# Altium schematic sheet CL10_FPGA.SchDoc
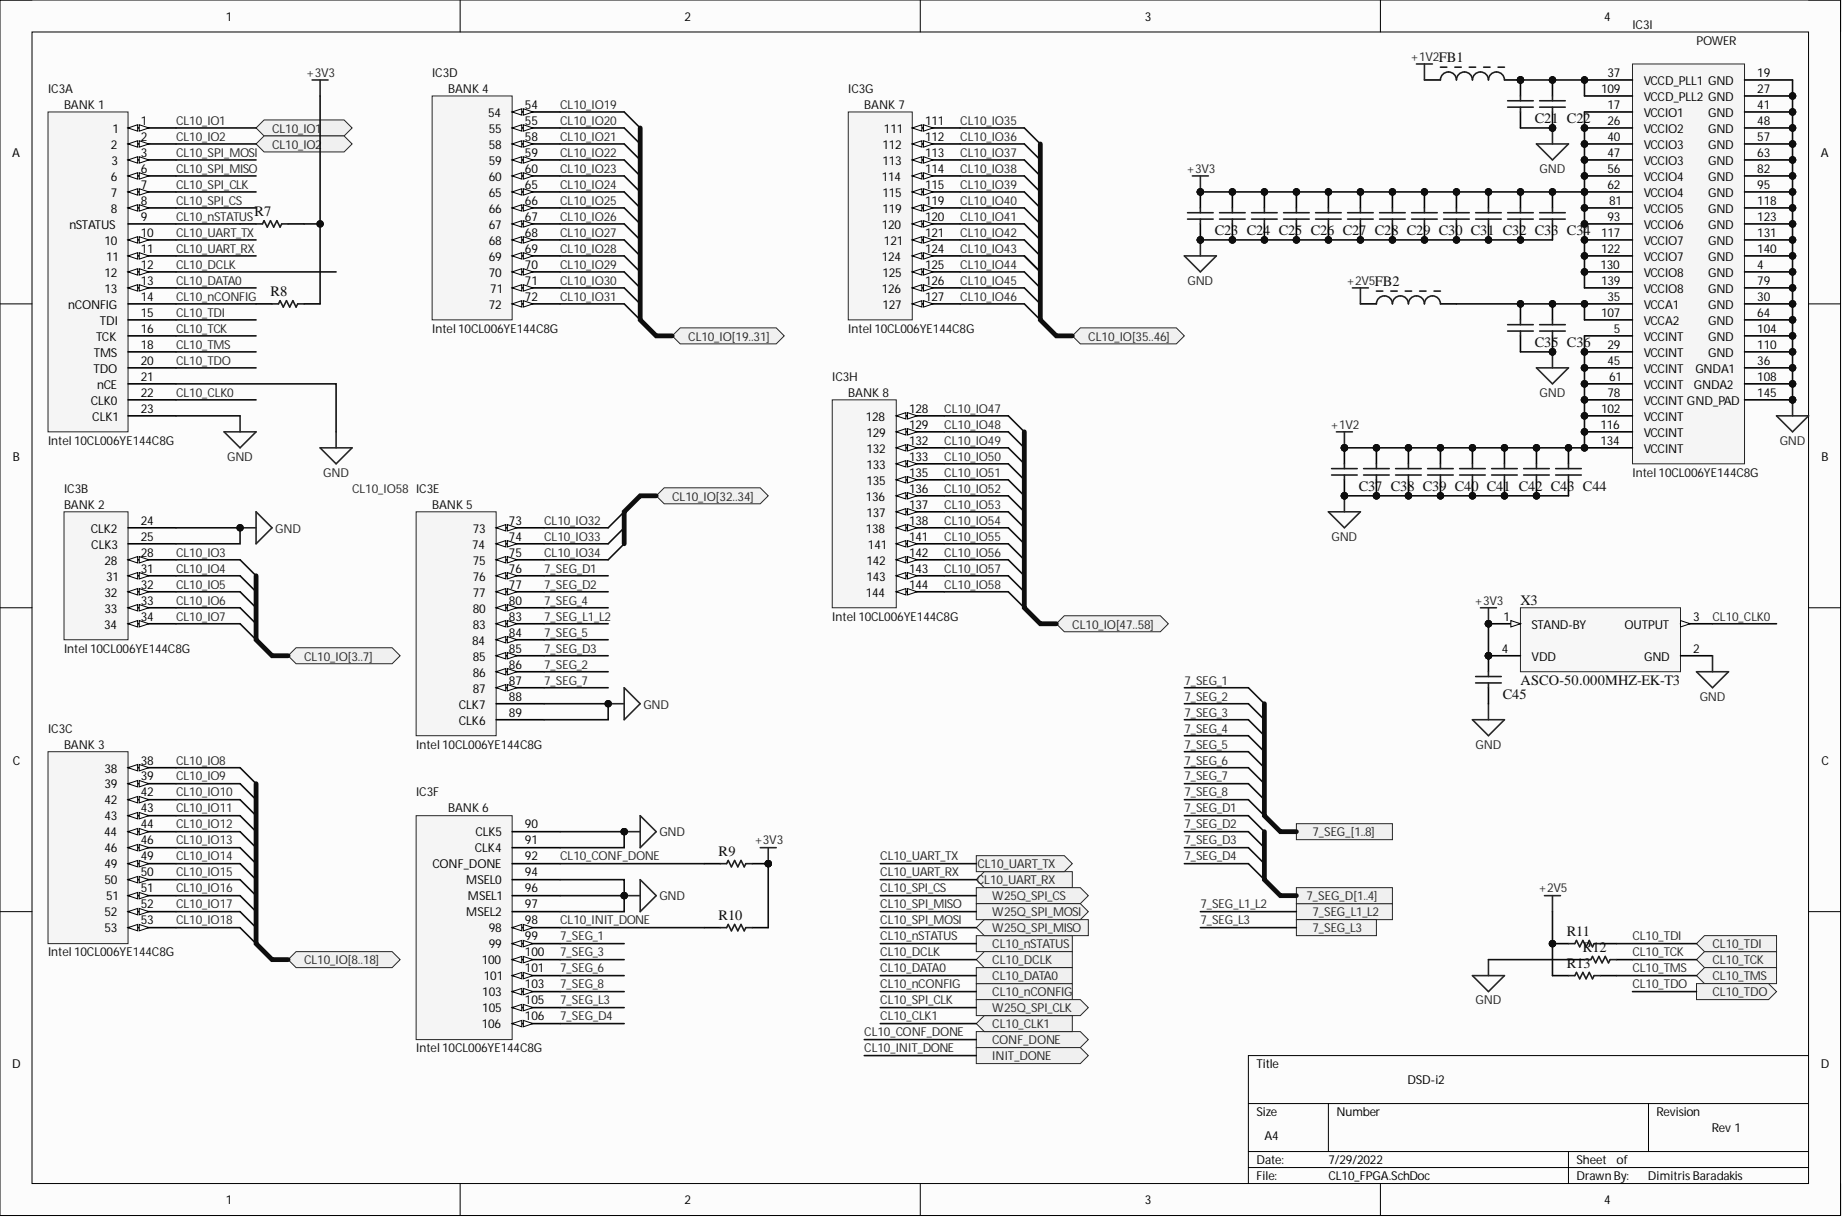
[diagram p0: CL10_FPGA.SchDoc]

## Parts
C21  Taiyo Yuden LMK063BJ104KP-F  [PCB-0002-00713-1]  (value not drawn)
C22  Taiyo Yuden LMK063BJ104KP-F  [PCB-0002-00713-1]  (value not drawn)
C23  Taiyo Yuden LMK063BJ104KP-F  [PCB-0002-00713-1]  (value not drawn)
C24  Taiyo Yuden LMK063BJ104KP-F  [PCB-0002-00713-1]  (value not drawn)
C25  Taiyo Yuden LMK063BJ104KP-F  [PCB-0002-00713-1]  (value not drawn)
C26  Taiyo Yuden LMK063BJ104KP-F  [PCB-0002-00713-1]  (value not drawn)
C27  Taiyo Yuden LMK063BJ104KP-F  [PCB-0002-00713-1]  (value not drawn)
C28  Taiyo Yuden LMK063BJ104KP-F  [PCB-0002-00713-1]  (value not drawn)
C29  Taiyo Yuden LMK063BJ104KP-F  [PCB-0002-00713-1]  (value not drawn)
C30  Taiyo Yuden LMK063BJ104KP-F  [PCB-0002-00713-1]  (value not drawn)
C31  Taiyo Yuden LMK063BJ104KP-F  [PCB-0002-00713-1]  (value not drawn)
C32  Taiyo Yuden LMK063BJ104KP-F  [PCB-0002-00713-1]  (value not drawn)
C33  Taiyo Yuden LMK063BJ104KP-F  [PCB-0002-00713-1]  (value not drawn)
C34  Taiyo Yuden LMK063BJ104KP-F  [PCB-0002-00713-1]  (value not drawn)
C35  Taiyo Yuden LMK063BJ104KP-F  [PCB-0002-00713-1]  (value not drawn)
C36  Taiyo Yuden LMK063BJ104KP-F  [PCB-0002-00713-1]  (value not drawn)
C37  Taiyo Yuden LMK063BJ104KP-F  [PCB-0002-00713-1]  (value not drawn)
C38  Taiyo Yuden LMK063BJ104KP-F  [PCB-0002-00713-1]  (value not drawn)
C39  Taiyo Yuden LMK063BJ104KP-F  [PCB-0002-00713-1]  (value not drawn)
C40  Taiyo Yuden LMK063BJ104KP-F  [PCB-0002-00713-1]  (value not drawn)
C41  Taiyo Yuden LMK063BJ104KP-F  [PCB-0002-00713-1]  (value not drawn)
C42  Taiyo Yuden LMK063BJ104KP-F  [PCB-0002-00713-1]  (value not drawn)
C43  Taiyo Yuden LMK063BJ104KP-F  [PCB-0002-00713-1]  (value not drawn)
C44  Taiyo Yuden LMK063BJ104KP-F  [PCB-0002-00713-1]  (value not drawn)
C45  Taiyo Yuden LMK063BJ104KP-F  [PCB-0002-00713-1]  (value not drawn)
FB1  BLM18PG181SN1D  [MURA-BLM18PG_KG-CHIP-2_V1]  (value not drawn)
FB2  BLM18PG181SN1D  [MURA-BLM18PG_KG-CHIP-2_V1]  (value not drawn)
IC3  Intel 10CL006YE144C8G  Manufacturer Part Number: 10CL006YE144C8G  [EQFP144_M]  (parts A,B,C,D,E,F,G,H,I)
R7  Bourns CR0201AJW-103GLF  [Bourns CR0201AJW-103GLF-Footprint-1]  (value not drawn)
R8  Bourns CR0201AJW-103GLF  [Bourns CR0201AJW-103GLF-Footprint-1]  (value not drawn)
R9  Bourns CR0201AJW-103GLF  [Bourns CR0201AJW-103GLF-Footprint-1]  (value not drawn)
R10  Bourns CR0201AJW-103GLF  [Bourns CR0201AJW-103GLF-Footprint-1]  (value not drawn)
R11  Bourns CR0201AJW-103GLF  [Bourns CR0201AJW-103GLF-Footprint-1]  (value not drawn)
R12  Bourns CR0201AFW-1001GLF  [Bourns CR0201AFW-1001GLF-Footprint-1]  (value not drawn)
R13  Bourns CR0201AJW-103GLF  [Bourns CR0201AJW-103GLF-Footprint-1]  (value not drawn)
X3  ASCO-50.000MHZ-EK-T3  [ABRA-ASCO-50.000MHZ-EK-T3-4_V]

## Ports
7_SEG_1, 7_SEG_2, 7_SEG_3, 7_SEG_4, 7_SEG_5, 7_SEG_6, 7_SEG_7, 7_SEG_8, 7_SEG_[1..8], 7_SEG_D1, 7_SEG_D2, 7_SEG_D3, 7_SEG_D4, 7_SEG_D[1..4], 7_SEG_L1_L2, 7_SEG_L3, CL10_CLK1, CL10_DATA0, CL10_DCLK, CL10_IO1, CL10_IO2, CL10_IO3, CL10_IO4, CL10_IO5, CL10_IO6, CL10_IO7, CL10_IO8, CL10_IO9, CL10_IO10, CL10_IO11, CL10_IO12, CL10_IO13, CL10_IO14, CL10_IO15, CL10_IO16, CL10_IO17, CL10_IO18, CL10_IO19, CL10_IO20, CL10_IO21, CL10_IO22, CL10_IO23, CL10_IO24, CL10_IO25, CL10_IO26, CL10_IO27, CL10_IO28, CL10_IO29, CL10_IO30, CL10_IO31, CL10_IO32, CL10_IO33, CL10_IO34, CL10_IO35, CL10_IO36, CL10_IO37, CL10_IO38, CL10_IO39, CL10_IO40, CL10_IO41, CL10_IO42, CL10_IO43, CL10_IO44, CL10_IO45, CL10_IO46, CL10_IO47, CL10_IO48, CL10_IO49, CL10_IO50, CL10_IO51, CL10_IO52, CL10_IO53, CL10_IO54, CL10_IO55, CL10_IO56, CL10_IO57, CL10_IO58, CL10_IO[3..7], CL10_IO[8..18], CL10_IO[19..31], CL10_IO[32..34], CL10_IO[35..46], CL10_IO[47..58], CL10_nCONFIG, CL10_nSTATUS, CL10_TCK, CL10_TDI, CL10_TDO, CL10_TMS, CL10_UART_RX, CL10_UART_TX, CONF_DONE, INIT_DONE, W25Q_SPI_CLK, W25Q_SPI_CS, W25Q_SPI_MISO, W25Q_SPI_MOSI

## Nets
+1V2: C37.2 C38.2 C39.2 C40.2 C41.2 C42.2 C43.2 C44.2 FB1.1 IC3.5(VCCINT) IC3.29(VCCINT) IC3.45(VCCINT) IC3.61(VCCINT) IC3.78(VCCINT) IC3.102(VCCINT) IC3.116(VCCINT) IC3.134(VCCINT)
+2V5: FB2.1 R11.1 R13.1
+3V3: C23.2 C24.2 C25.2 C26.2 C27.2 C28.2 C29.2 C30.2 C31.2 C32.2 C33.2 C34.2 C45.2 IC3.17(VCCIO1) IC3.26(VCCIO2) IC3.40(VCCIO3) IC3.47(VCCIO3) IC3.56(VCCIO4) IC3.62(VCCIO4) IC3.81(VCCIO5) IC3.93(VCCIO6) IC3.117(VCCIO7) IC3.122(VCCIO7) IC3.130(VCCIO8) IC3.139(VCCIO8) R7.2 R8.2 R9.2 R10.2 X3.1(STAND-BY) X3.4(VDD)
GND: C21.1 C22.1 C23.1 C24.1 C25.1 C26.1 C27.1 C28.1 C29.1 C30.1 C31.1 C32.1 C33.1 C34.1 C35.1 C36.1 C37.1 C38.1 C39.1 C40.1 C41.1 C42.1 C43.1 C44.1 C45.1 IC3.4(GND) IC3.19(GND) IC3.21(nCE) IC3.23(CLK1) IC3.24(CLK2) IC3.25(CLK3) IC3.27(GND) IC3.30(GND) IC3.36(GNDA1) IC3.41(GND) IC3.48(GND) IC3.57(GND) IC3.63(GND) IC3.64(GND) IC3.79(GND) IC3.82(GND) IC3.88(CLK7) IC3.89(CLK6) IC3.90(CLK5) IC3.91(CLK4) IC3.94(MSEL0) IC3.95(GND) IC3.96(MSEL1) IC3.97(MSEL2) IC3.104(GND) IC3.108(GNDA2) IC3.110(GND) IC3.118(GND) IC3.123(GND) IC3.131(GND) IC3.140(GND) IC3.145(GND_PAD) R12.1 X3.2(GND)
7_SEG_1: IC3.99 ; port 7_SEG_[1..8]  [also labelled ]
7_SEG_2: IC3.86 ; port 7_SEG_[1..8]  [also labelled ]
7_SEG_3: IC3.100 ; port 7_SEG_[1..8]  [also labelled ]
7_SEG_4: IC3.80 ; port 7_SEG_[1..8]  [also labelled ]
7_SEG_5: IC3.84 ; port 7_SEG_[1..8]  [also labelled ]
7_SEG_6: IC3.101 ; port 7_SEG_[1..8]  [also labelled ]
7_SEG_7: IC3.87 ; port 7_SEG_[1..8]  [also labelled ]
7_SEG_8: IC3.103 ; port 7_SEG_[1..8]  [also labelled ]
7_SEG_D1: IC3.76 ; port 7_SEG_D[1..4]  [also labelled ]
7_SEG_D2: IC3.77 ; port 7_SEG_D[1..4]  [also labelled ]
7_SEG_D3: IC3.85 ; port 7_SEG_D[1..4]  [also labelled ]
7_SEG_D4: IC3.106 ; port 7_SEG_D[1..4]  [also labelled ]
7_SEG_L1_L2: IC3.83 ; port 7_SEG_L1_L2
7_SEG_L3: IC3.105 ; port 7_SEG_L3
CL10_CLK0: IC3.22(CLK0) X3.3(OUTPUT)
CL10_CONF_DONE: IC3.92(CONF_DONE) R9.1 ; port CONF_DONE
CL10_DATA0: IC3.13 ; port CL10_DATA0
CL10_DCLK: IC3.12 ; port CL10_DCLK
CL10_INIT_DONE: IC3.98 R10.1 ; port INIT_DONE
CL10_IO1: IC3.1 ; port CL10_IO1
CL10_IO2: IC3.2 ; port CL10_IO2
CL10_IO3: IC3.28 ; port CL10_IO[3..7]  [also labelled ]
CL10_IO4: IC3.31 ; port CL10_IO[3..7]  [also labelled ]
CL10_IO5: IC3.32 ; port CL10_IO[3..7]  [also labelled ]
CL10_IO6: IC3.33 ; port CL10_IO[3..7]  [also labelled ]
CL10_IO7: IC3.34 ; port CL10_IO[3..7]  [also labelled ]
CL10_IO8: IC3.38 ; port CL10_IO[8..18]  [also labelled ]
CL10_IO9: IC3.39 ; port CL10_IO[8..18]  [also labelled ]
CL10_IO10: IC3.42 ; port CL10_IO[8..18]  [also labelled ]
CL10_IO11: IC3.43 ; port CL10_IO[8..18]  [also labelled ]
CL10_IO12: IC3.44 ; port CL10_IO[8..18]  [also labelled ]
CL10_IO13: IC3.46 ; port CL10_IO[8..18]  [also labelled ]
CL10_IO14: IC3.49 ; port CL10_IO[8..18]  [also labelled ]
CL10_IO15: IC3.50 ; port CL10_IO[8..18]  [also labelled ]
CL10_IO16: IC3.51 ; port CL10_IO[8..18]  [also labelled ]
CL10_IO17: IC3.52 ; port CL10_IO[8..18]  [also labelled ]
CL10_IO18: IC3.53 ; port CL10_IO[8..18]  [also labelled ]
CL10_IO19: IC3.54 ; port CL10_IO[19..31]  [also labelled ]
CL10_IO20: IC3.55 ; port CL10_IO[19..31]  [also labelled ]
CL10_IO21: IC3.58 ; port CL10_IO[19..31]  [also labelled ]
CL10_IO22: IC3.59 ; port CL10_IO[19..31]  [also labelled ]
CL10_IO23: IC3.60 ; port CL10_IO[19..31]  [also labelled ]
CL10_IO24: IC3.65 ; port CL10_IO[19..31]  [also labelled ]
CL10_IO25: IC3.66 ; port CL10_IO[19..31]  [also labelled ]
CL10_IO26: IC3.67 ; port CL10_IO[19..31]  [also labelled ]
CL10_IO27: IC3.68 ; port CL10_IO[19..31]  [also labelled ]
CL10_IO28: IC3.69 ; port CL10_IO[19..31]  [also labelled ]
CL10_IO29: IC3.70 ; port CL10_IO[19..31]  [also labelled ]
CL10_IO30: IC3.71 ; port CL10_IO[19..31]  [also labelled ]
CL10_IO31: IC3.72 ; port CL10_IO[19..31]  [also labelled ]
CL10_IO32: IC3.73 ; port CL10_IO[32..34]  [also labelled ]
CL10_IO33: IC3.74 ; port CL10_IO[32..34]  [also labelled ]
CL10_IO34: IC3.75 ; port CL10_IO[32..34]  [also labelled ]
CL10_IO35: IC3.111 ; port CL10_IO[35..46]  [also labelled ]
CL10_IO36: IC3.112 ; port CL10_IO[35..46]  [also labelled ]
CL10_IO37: IC3.113 ; port CL10_IO[35..46]  [also labelled ]
CL10_IO38: IC3.114 ; port CL10_IO[35..46]  [also labelled ]
CL10_IO39: IC3.115 ; port CL10_IO[35..46]  [also labelled ]
CL10_IO40: IC3.119 ; port CL10_IO[35..46]  [also labelled ]
CL10_IO41: IC3.120 ; port CL10_IO[35..46]  [also labelled ]
CL10_IO42: IC3.121 ; port CL10_IO[35..46]  [also labelled ]
CL10_IO43: IC3.124 ; port CL10_IO[35..46]  [also labelled ]
CL10_IO44: IC3.125 ; port CL10_IO[35..46]  [also labelled ]
CL10_IO45: IC3.126 ; port CL10_IO[35..46]  [also labelled ]
CL10_IO46: IC3.127 ; port CL10_IO[35..46]  [also labelled ]
CL10_IO47: IC3.128 ; port CL10_IO[47..58]  [also labelled ]
CL10_IO48: IC3.129 ; port CL10_IO[47..58]  [also labelled ]
CL10_IO49: IC3.132 ; port CL10_IO[47..58]  [also labelled ]
CL10_IO50: IC3.133 ; port CL10_IO[47..58]  [also labelled ]
CL10_IO51: IC3.135 ; port CL10_IO[47..58]  [also labelled ]
CL10_IO52: IC3.136 ; port CL10_IO[47..58]  [also labelled ]
CL10_IO53: IC3.137 ; port CL10_IO[47..58]  [also labelled ]
CL10_IO54: IC3.138 ; port CL10_IO[47..58]  [also labelled ]
CL10_IO55: IC3.141 ; port CL10_IO[47..58]  [also labelled ]
CL10_IO56: IC3.142 ; port CL10_IO[47..58]  [also labelled ]
CL10_IO57: IC3.143 ; port CL10_IO[47..58]  [also labelled ]
CL10_IO58: IC3.144 ; port CL10_IO[47..58]  [also labelled ]
CL10_nCONFIG: IC3.14(nCONFIG) R8.1 ; port CL10_nCONFIG
CL10_nSTATUS: IC3.9(nSTATUS) R7.1 ; port CL10_nSTATUS
CL10_SPI_CLK: IC3.7 ; port W25Q_SPI_CLK
CL10_SPI_CS: IC3.8 ; port W25Q_SPI_CS
CL10_SPI_MISO: IC3.6 ; port W25Q_SPI_MOSI
CL10_SPI_MOSI: IC3.3 ; port W25Q_SPI_MISO
CL10_TCK: IC3.16(TCK) R12.2 ; port CL10_TCK
CL10_TDI: IC3.15(TDI) R11.2 ; port CL10_TDI
CL10_TDO: IC3.20(TDO) ; port CL10_TDO
CL10_TMS: IC3.18(TMS) R13.2 ; port CL10_TMS
CL10_UART_RX: IC3.11 ; port CL10_UART_RX
CL10_UART_TX: IC3.10 ; port CL10_UART_TX
NetC21_2: C21.2 C22.2 FB1.2 IC3.37(VCCD_PLL1) IC3.109(VCCD_PLL2)
NetC35_2: C35.2 C36.2 FB2.2 IC3.35(VCCA1) IC3.107(VCCA2)
CL10_CLK1: ; port CL10_CLK1
N00002_[3..7]: ; port CL10_IO[3..7]
N00003_[47..58]: ; port CL10_IO[47..58]
N00004_[32..34]: ; port CL10_IO[32..34]
N00005_[8..18]: ; port CL10_IO[8..18]
N00006_[35..46]: ; port CL10_IO[35..46]
N00007_[19..31]: ; port CL10_IO[19..31]

## Library data of the parts
C21-C45 = CMP-e92820824df736ef-2: 0201 0.1 uF 10 V ±10% Tolerance X5R SMT Multilayer Ceramic Capacitor; Capacitance: 100 nF; Lead-Free Status: Lead Free; Voltage Rating (DC): 10.0 V; Capacitance Tolerance: ±10%; Case/Package: 0201; Component Kind: Standard; Dielectric Characteristic: X5R; Halogen Free Status: Halogen Free; Library Name: My Personal Space; Lifecycle Status: Active; Manufacturer Part Number: LMK063BJ104KP-F; Mounting Style: Surface Mount; Number of Pins: 2; Octopart URL: https://octopart.com/lmk063bj104kp-f-taiyo+yuden-10518969; OctopartID: e92820824df736ef; Operating Temperature: -55.0 °C to 85.0 °C; Packaging: Cut Tape (CT); RoHS: Compliant
FB1 FB2 = CMP-886511-3: Chip Ferrite Bead for Power Lines, 180 Ohm, 1500 mA, -55 to 125 degC, 1.6 x 0.8 x 0.95 mm SMD, Tape and Reel; Current Rating: 1.5 A; Lead-Free Status: Lead Free; Number of Circuits: 1; Resistance: 180.0 Ω; Shielding: No; Case/Package: 0603; Component Kind: Standard; Library Name: My Personal Space; Manufacturer Part Number: BLM18PG181SN1D; Mounting Style: Surface Mount; Number of Pins: 2; Octopart Url: https://octopart.com/blm18pg181sn1d-murata-755298; OctopartID: 1055d954b351cf92; Packaging: Cut Tape (CT); REACH SVHC Compliance: No SVHC; RoHS: Compliant; Size-Length: 0.0016 m; Size-Width: 0.0008 m
IC3 = CMP-01bdcd990a8fde7a-4: FPGA Cyclone® 10 LP Family 6272 Cells 144-Pin EQFP Tray; Component Kind: Standard; Library Name: My Personal Space; Octopart Url: https://gr.mouser.com/ProductDetail/Intel-Altera/10CL006YE144C8G?qs=Mv7BduZupUiyx%2F63DfbYXA%3D%3D; OctopartID: 01bdcd990a8fde7a
R7-R11 R13 = CMP-0c15c370377fd3d2-2: Thick Film Chip Resistor; 0201 10k 5% 50mW TC200; Component Kind: Standard; Library Name: My Personal Space; Manufacturer Part Number: CR0201AJW-103GLF; Octopart Url: https://octopart.com/cr0201ajw-103glf-bourns-107945484; OctopartID: 0c15c370377fd3d2
R12 = CMP-1d3dd2582652c2fa-2: Res Thick Film 0201 1K Ohm 1% 0.05W(1/20W) ±200ppm/°C Pad SMD Automotive T/R; Component Kind: Standard; Library Name: My Personal Space; Manufacturer Part Number: CR0201AFW-1001GLF; Octopart Url: https://octopart.com/cr0201afw-1001glf-bourns-107945481; OctopartID: 1d3dd2582652c2fa
X3 = CMP-10504987-4: Crystal Clock Oscillator, 50 MHz, -20 to 70 degC, 1.6 x 1.2 x 0.7 mm SMD; Component Kind: Standard; Library Name: My Personal Space; Manufacturer Part Number: ASCO-50.000MHZ-EK-T3; Octopart Url: https://octopart.com/asco-50.000mhz-ek-t3-abracon-29304151; OctopartID: 50b7980250868f70; RoHS: Compliant
